# Altium schematic sheet MCU.SchDoc(MCU) (continued)

## Library data of the parts
C13 C19 = TMK212BBJ106KGHT: 25V 10uF X5R 卤10% 0805 Multilayer Ceramic Capacitors MLCC - SMD/SMT ROHS; Manufacturer: TAIYO YUDEN; Manufacturer Package Identifier: 0805 (2012 metric); Tolerance: 10%; Value: 10µF; Case Code (Imperial): 0805; Case Code (Metric): 2012; Case/Package: 0805; Category: Ceramic Capacitors; Component Kind: Standard; ComponentLink1Description: https://datasheet.octopart.com:443/TMK212BBJ106KGHT-Taiyo-Yuden-datasheet-181660554.pdf?src-supplier=element14+APAC; ComponentLink1URL: https://datasheet.octopart.com:443/TMK212BBJ106KGHT-Taiyo-Yuden-datasheet-181660554.pdf?src-supplier=element14+APAC; ComponentLink2Description: https://datasheet.octopart.com:443/TMK212BBJ106KGHT-Taiyo-Yuden-datasheet-140424376.pdf?src-supplier=Master+Electronics; ComponentLink2URL: https://datasheet.octopart.com:443/TMK212BBJ106KGHT-Taiyo-Yuden-datasheet-140424376.pdf?src-supplier=Master+Electronics; ComponentLink3Description: https://datasheet.octopart.com:443/TMK212BBJ106KGHT-Taiyo-Yuden-datasheet-137916716.pdf?src-supplier=Taiyo+Yuden+SCT; ComponentLink3URL: https://datasheet.octopart.com:443/TMK212BBJ106KGHT-Taiyo-Yuden-datasheet-137916716.pdf?src-supplier=Taiyo+Yuden+SCT; ComponentLink4Description: https://datasheet.octopart.com:443/TMK212BBJ106KGHT-Taiyo-Yuden-datasheet-97186031.pdf?src-supplier=Future+Electronics; ComponentLink4URL: https://datasheet.octopart.com:443/TMK212BBJ106KGHT-Taiyo-Yuden-datasheet-97186031.pdf?src-supplier=Future+Electronics; Country of Origin: Japan, Mainland China, Malaysia, South Korea; Dielectric: X5R; Dielectric Material: Ceramic; Export Control Classification Number (ECCN) Code: EAR99; Harmonized Tariff Schedule (HTS) Code: 8532.24.00.20; Height: 1.25mm; Introduction Date: 2014-09-25; Length: 2mm; Lifecycle Status: Production; Manufacturer Part Number: TMK212BBJ106KGHT; Material: Ceramic; Max Operating Temperature: 85°C; Min Operating Temperature: -55°C; Mounting Technology: Surface Mount; Number of Terminals: 2; Packaging: Tape & Reel (TR); Pricing: 1=0.3, 10=0.178, 50=0.1288, 100=0.1137, 500=0.08824 (USD); Ratings: AEC-Q200; REACH SVHC: Yes; RoHS: Compliant; Stock: 129716; Supplier: DigiKey; Supplier Part Number: 587-4334-6-ND; Termination: SMD/SMT; Thickness: 1.3462mm; Voltage Rating: 25V; Voltage Rating (DC): 25V; Weight: 5.499807mg; Width: 1.25mm; Library Name: Tremelo_Pedal.SchLib
C14-C18 C21 C23 = C0402C104K4RACAUTO: CAP CER 0.1UF 16V X7R 0402 / 0.1 µF ±10% 16V Ceramic Capacitor X7R 0402 (1005 Metric); Manufacturer: Yageo Group; Dissipation Factor: 3.5%; Value: 100nF; Case Code (Imperial): 0402; Case Code (Metric): 1005; Case/Package: 0402; Category: Ceramic Capacitors; China RoHS: Compliant; Component Kind: Standard; ComponentLink1Description: https://datasheet.octopart.com:443/C0402C104K4RACAUTO-KEMET-datasheet-178087915.pdf?src-supplier=IHS; ComponentLink1URL: https://datasheet.octopart.com:443/C0402C104K4RACAUTO-KEMET-datasheet-178087915.pdf?src-supplier=IHS; ComponentLink2Description: https://datasheet.octopart.com:443/C0402C104K4RACAUTO-KEMET-datasheet-137357759.pdf?src-supplier=Upverter; ComponentLink2URL: https://datasheet.octopart.com:443/C0402C104K4RACAUTO-KEMET-datasheet-137357759.pdf?src-supplier=Upverter; ComponentLink3Description: https://datasheet.octopart.com:443/C0402C104K4RACAUTO-KEMET-datasheet-149521626.pdf?src-supplier=LKR; ComponentLink3URL: https://datasheet.octopart.com:443/C0402C104K4RACAUTO-KEMET-datasheet-149521626.pdf?src-supplier=LKR; ComponentLink4Description: https://datasheet.octopart.com:443/C0402C104K4RACAUTO-KEMET-datasheet-102647416.pdf?src-supplier=Farnell; ComponentLink4URL: https://datasheet.octopart.com:443/C0402C104K4RACAUTO-KEMET-datasheet-102647416.pdf?src-supplier=Farnell; ComponentLink5Description: https://datasheet.octopart.com:443/C0402C104K4RACAUTO-KEMET-datasheet-138896278.pdf?src-supplier=Farnell; ComponentLink5URL: https://datasheet.octopart.com:443/C0402C104K4RACAUTO-KEMET-datasheet-138896278.pdf?src-supplier=Farnell; ComponentLink6Description: https://datasheet.octopart.com:443/C0402C104K4RACAUTO-Kemet-datasheet-14356739.pdf?src-supplier=Farnell; ComponentLink6URL: https://datasheet.octopart.com:443/C0402C104K4RACAUTO-Kemet-datasheet-14356739.pdf?src-supplier=Farnell; ComponentLink7Description: https://datasheet.octopart.com:443/C0402C104K4RAC-AUTO-Kemet-datasheet-11517047.pdf?src-supplier=Farnell; ComponentLink7URL: https://datasheet.octopart.com:443/C0402C104K4RAC-AUTO-Kemet-datasheet-11517047.pdf?src-supplier=Farnell; ComponentLink8Description: https://datasheet.octopart.com:443/C0402C104K4RACAUTO-KEMET-datasheet-153706760.pdf?src-supplier=Electro+Sonic; ComponentLink8URL: https://datasheet.octopart.com:443/C0402C104K4RACAUTO-KEMET-datasheet-153706760.pdf?src-supplier=Electro+Sonic; ComponentLink9Description: https://datasheet.octopart.com:443/C0402C104K4RACAUTO-KEMET-datasheet-37550250.pdf?src-supplier=Electro+Sonic; ComponentLink9URL: https://datasheet.octopart.com:443/C0402C104K4RACAUTO-KEMET-datasheet-37550250.pdf?src-supplier=Electro+Sonic; ComponentLink10Description: https://datasheet.octopart.com:443/C0402C104K4RACAUTO-KEMET-datasheet-81638344.pdf?src-supplier=Newark; ComponentLink10URL: https://datasheet.octopart.com:443/C0402C104K4RACAUTO-KEMET-datasheet-81638344.pdf?src-supplier=Newark; ComponentLink11Description: https://datasheet.octopart.com:443/C0402C104K4RAC-AUTO-Kemet-datasheet-10850653.pdf?src-supplier=Newark; ComponentLink11URL: https://datasheet.octopart.com:443/C0402C104K4RAC-AUTO-Kemet-datasheet-10850653.pdf?src-supplier=Newark; ComponentLink12Description: https://datasheet.octopart.com:443/C0402C104K4RACAUTO-KEMET-datasheet-176142086.pdf?src-supplier=Newark; ComponentLink12URL: https://datasheet.octopart.com:443/C0402C104K4RACAUTO-KEMET-datasheet-176142086.pdf?src-supplier=Newark; ComponentLink13Description: https://datasheet.octopart.com:443/C0402C104K4RACAUTO-KEMET-datasheet-101575470.pdf?src-supplier=Newark; ComponentLink13URL: https://datasheet.octopart.com:443/C0402C104K4RACAUTO-KEMET-datasheet-101575470.pdf?src-supplier=Newark; ComponentLink14Description: https://datasheet.octopart.com:443/C0402C104K4RACAUTO-Kemet-datasheet-66532075.pdf?src-supplier=element14+APAC; ComponentLink14URL: https://datasheet.octopart.com:443/C0402C104K4RACAUTO-Kemet-datasheet-66532075.pdf?src-supplier=element14+APAC; ComponentLink15Description: https://datasheet.octopart.com:443/C0402C104K4RACAUTO-Kemet-datasheet-17269585.pdf?src-supplier=element14+APAC; ComponentLink15URL: https://datasheet.octopart.com:443/C0402C104K4RACAUTO-Kemet-datasheet-17269585.pdf?src-supplier=element14+APAC; ComponentLink16Description: https://datasheet.octopart.com:443/C0402C104K4RACAUTO-Kemet-datasheet-66327795.pdf?src-supplier=element14+APAC; ComponentLink16URL: https://datasheet.octopart.com:443/C0402C104K4RACAUTO-Kemet-datasheet-66327795.pdf?src-supplier=element14+APAC; ComponentLink17Description: https://datasheet.octopart.com:443/C0402C104K4RACAUTO-KEMET-datasheet-83968784.pdf?src-supplier=TME; ComponentLink17URL: https://datasheet.octopart.com:443/C0402C104K4RACAUTO-KEMET-datasheet-83968784.pdf?src-supplier=TME; ComponentLink18Description: https://datasheet.octopart.com:443/C0402C104K4RACAUTO-KEMET-datasheet-98355702.pdf?src-supplier=TME; ComponentLink18URL: https://datasheet.octopart.com:443/C0402C104K4RACAUTO-KEMET-datasheet-98355702.pdf?src-supplier=TME; ComponentLink19Description: https://datasheet.octopart.com/C0402C104K4RACAUTO-KEMET-datasheet-84169754.pdf; ComponentLink19URL: https://datasheet.octopart.com/C0402C104K4RACAUTO-KEMET-datasheet-84169754.pdf; ComponentLink20Description: https://datasheet.octopart.com:443/C0402C104K4RACAUTO-KEMET-datasheet-189767464.pdf?src-supplier=ODG+(Origin+Data+Global); ComponentLink20URL: https://datasheet.octopart.com:443/C0402C104K4RACAUTO-KEMET-datasheet-189767464.pdf?src-supplier=ODG+(Origin+Data+Global); Country of Origin: Mexico; Depth: 500µm; Dielectric: X7R; Dielectric Material: Ceramic; Export Control Classification Number (ECCN) Code: EAR99; Harmonized Tariff Schedule (HTS) Code: 8532.24.00.20; Height: 500µm; Introduction Date: 2012-06-13; Length: 1mm; Lifecycle Status: Production; Manufacturer Part Number: C0402C104K4RACAUTO; Material: Ceramic; Max Operating Temperature: 125°C; Min Operating Temperature: -55°C; Mounting Technology: Surface Mount; Number of Terminals: 2; Packaging: Cut Tape; Pins: 2; Pricing: 1=0.19, 10=0.107, 50=0.0748, 100=0.0651, 500=0.04872 (USD); Radiation Hardening: No; Ratings: AEC-Q200; REACH SVHC: Yes; RoHS: Compliant; Schedule B: 8532240020, 8532240020|8532240020, 8532240020|8532240020|8532240020, 8532240020|8532240020|8532240020|8532240020; Stock: 1110690; Supplier: DigiKey; Supplier Part Number: 399-6828-6-ND; Termination: SMD/SMT; Thickness: 558.8µm; Tolerance: 10%; Voltage Rating: 16V; Voltage Rating (DC): 16V; Width: 500µm; Library Name: Tremelo_Pedal.SchLib
C20 = CC0603KRX7R7BB105: 16V 1uF X7R ±10% 0603 Multilayer Ceramic Capacitors MLCC - SMD/SMT ROHS; Manufacturer: Yageo Group; Dissipation Factor: 5%; Value: 1µF; Case Code (Imperial): 0603; Case Code (Metric): 1608; Case/Package: 0603; Category: Ceramic Capacitors; China RoHS: Compliant; Component Kind: Standard; ComponentLink1Description: https://datasheet.octopart.com:443/CC0603KRX7R7BB105-Yageo-datasheet-177165144.pdf?src-supplier=IHS; ComponentLink1URL: https://datasheet.octopart.com:443/CC0603KRX7R7BB105-Yageo-datasheet-177165144.pdf?src-supplier=IHS; ComponentLink2Description: https://datasheet.octopart.com:443/CC0603KRX7R7BB105-Yageo-datasheet-82288757.pdf?src-supplier=Upverter; ComponentLink2URL: https://datasheet.octopart.com:443/CC0603KRX7R7BB105-Yageo-datasheet-82288757.pdf?src-supplier=Upverter; ComponentLink3Description: https://datasheet.octopart.com/CC0603KRX7R7BB105-Yageo-datasheet-10883670.pdf; ComponentLink3URL: https://datasheet.octopart.com/CC0603KRX7R7BB105-Yageo-datasheet-10883670.pdf; ComponentLink4Description: https://datasheet.octopart.com/CC0603KRX7R7BB105-Yageo-datasheet-12526321.pdf; ComponentLink4URL: https://datasheet.octopart.com/CC0603KRX7R7BB105-Yageo-datasheet-12526321.pdf; ComponentLink5Description: https://datasheet.octopart.com:443/CC0603KRX7R7BB105-Yageo-datasheet-181968648.pdf?src-supplier=Newark; ComponentLink5URL: https://datasheet.octopart.com:443/CC0603KRX7R7BB105-Yageo-datasheet-181968648.pdf?src-supplier=Newark; ComponentLink6Description: https://datasheet.octopart.com:443/CC0603KRX7R7BB105-Yageo-datasheet-181968639.pdf?src-supplier=Newark; ComponentLink6URL: https://datasheet.octopart.com:443/CC0603KRX7R7BB105-Yageo-datasheet-181968639.pdf?src-supplier=Newark; ComponentLink7Description: https://datasheet.octopart.com:443/CC0603KRX7R7BB105-Yageo-datasheet-519481.pdf?src-supplier=Newark; ComponentLink7URL: https://datasheet.octopart.com:443/CC0603KRX7R7BB105-Yageo-datasheet-519481.pdf?src-supplier=Newark; ComponentLink8Description: https://datasheet.octopart.com:443/CC0603KRX7R7BB105-Yageo-datasheet-147453679.pdf?src-supplier=Newark; ComponentLink8URL: https://datasheet.octopart.com:443/CC0603KRX7R7BB105-Yageo-datasheet-147453679.pdf?src-supplier=Newark; ComponentLink9Description: https://datasheet.octopart.com:443/CC0603KRX7R7BB105-Yageo-datasheet-62336348.pdf?src-supplier=Farnell; ComponentLink9URL: https://datasheet.octopart.com:443/CC0603KRX7R7BB105-Yageo-datasheet-62336348.pdf?src-supplier=Farnell; ComponentLink10Description: https://datasheet.octopart.com:443/CC0603KRX7R7BB105-Yageo-datasheet-151948686.pdf?src-supplier=Farnell; ComponentLink10URL: https://datasheet.octopart.com:443/CC0603KRX7R7BB105-Yageo-datasheet-151948686.pdf?src-supplier=Farnell; ComponentLink11Description: https://datasheet.octopart.com:443/CC0603KRX7R7BB105-Yageo-datasheet-15895757.pdf?src-supplier=element14+APAC; ComponentLink11URL: https://datasheet.octopart.com:443/CC0603KRX7R7BB105-Yageo-datasheet-15895757.pdf?src-supplier=element14+APAC; ComponentLink12Description: https://datasheet.octopart.com:443/CC0603KRX7R7BB105-Yageo-Group-datasheet-184163374.pdf?src-supplier=LCSC; ComponentLink12URL: https://datasheet.octopart.com:443/CC0603KRX7R7BB105-Yageo-Group-datasheet-184163374.pdf?src-supplier=LCSC; ComponentLink13Description: https://datasheet.octopart.com:443/CC0603KRX7R7BB105-Yageo-datasheet-166511530.pdf?src-supplier=Future+Electronics; ComponentLink13URL: https://datasheet.octopart.com:443/CC0603KRX7R7BB105-Yageo-datasheet-166511530.pdf?src-supplier=Future+Electronics; ComponentLink14Description: https://datasheet.octopart.com:443/CC0603KRX7R7BB105-Yageo-datasheet-137700082.pdf?src-supplier=Future+Electronics; ComponentLink14URL: https://datasheet.octopart.com:443/CC0603KRX7R7BB105-Yageo-datasheet-137700082.pdf?src-supplier=Future+Electronics; ComponentLink15Description: https://datasheet.octopart.com:443/CC0603KRX7R7BB105-Yageo-datasheet-137700084.pdf?src-supplier=Future+Electronics; ComponentLink15URL: https://datasheet.octopart.com:443/CC0603KRX7R7BB105-Yageo-datasheet-137700084.pdf?src-supplier=Future+Electronics; ComponentLink16Description: https://datasheet.octopart.com:443/CC0603KRX7R7BB105-Yageo-datasheet-27626582.pdf?src-supplier=Future+Electronics; ComponentLink16URL: https://datasheet.octopart.com:443/CC0603KRX7R7BB105-Yageo-datasheet-27626582.pdf?src-supplier=Future+Electronics; ComponentLink17Description: https://datasheet.octopart.com:443/CC0603KRX7R7BB105-Yageo-Group-datasheet-165992755.pdf?src-supplier=ODG+(Origin+Data+Global); ComponentLink17URL: https://datasheet.octopart.com:443/CC0603KRX7R7BB105-Yageo-Group-datasheet-165992755.pdf?src-supplier=ODG+(Origin+Data+Global); ComponentLink18Description: https://datasheet.octopart.com:443/CC0603KRX7R7BB105-Yageo-datasheet-140260305.pdf?src-supplier=SOS+electronic; ComponentLink18URL: https://datasheet.octopart.com:443/CC0603KRX7R7BB105-Yageo-datasheet-140260305.pdf?src-supplier=SOS+electronic; ComponentLink19Description: https://datasheet.octopart.com:443/CC0603KRX7R7BB105-Yageo-datasheet-8701427.pdf?src-supplier=element14; ComponentLink19URL: https://datasheet.octopart.com:443/CC0603KRX7R7BB105-Yageo-datasheet-8701427.pdf?src-supplier=element14; ComponentLink20Description: https://datasheet.octopart.com:443/CC0603KRX7R7BB105-Yageo-datasheet-499490.pdf?src-supplier=element14; ComponentLink20URL: https://datasheet.octopart.com:443/CC0603KRX7R7BB105-Yageo-datasheet-499490.pdf?src-supplier=element14; ComponentLink21Description: https://datasheet.octopart.com:443/CC0603KRX7R7BB105-Yageo-datasheet-5301394.pdf?src-supplier=element14; ComponentLink21URL: https://datasheet.octopart.com:443/CC0603KRX7R7BB105-Yageo-datasheet-5301394.pdf?src-supplier=element14; ComponentLink22Description: https://datasheet.octopart.com:443/CC0603KRX7R7BB105-Yageo-datasheet-17600895.pdf?src-supplier=TME; ComponentLink22URL: https://datasheet.octopart.com:443/CC0603KRX7R7BB105-Yageo-datasheet-17600895.pdf?src-supplier=TME; ComponentLink23Description: http://sigma.octopart.com:80/rohs_statement/Surface-Mount-Ceramic-Multilayer-Capacitors%3B-General-Purpose-%26-High-Capacitance-Class-2%2C-X7R-Yageo-family-124.pdf?src-supplier=Compliance+Statements; ComponentLink23URL: http://sigma.octopart.com:80/rohs_statement/Surface-Mount-Ceramic-Multilayer-Capacitors%3B-General-Purpose-%26-High-Capacitance-Class-2%2C-X7R-Yageo-family-124.pdf?src-supplier=Compliance+Statements; ComponentLink24Description: http://sigma.octopart.com:80/reach_statement/Surface-Mount-Ceramic-Multilayer-Capacitors%3B-General-Purpose-%26-High-Capacitance-Class-2%2C-X7R-Yageo-family-125.pdf?src-supplier=Compliance+Statements; ComponentLink24URL: http://sigma.octopart.com:80/reach_statement/Surface-Mount-Ceramic-Multilayer-Capacitors%3B-General-Purpose-%26-High-Capacitance-Class-2%2C-X7R-Yageo-family-125.pdf?src-supplier=Compliance+Statements; ComponentLink25Description: http://sigma.octopart.com:80/conflict_mineral_statement/Yageo-company-3.pdf?src-supplier=Compliance+Statements; ComponentLink25URL: http://sigma.octopart.com:80/conflict_mineral_statement/Yageo-company-3.pdf?src-supplier=Compliance+Statements; ComponentLink26Description: http://sigma.octopart.com:80/rohs_statement/Yageo-company-2.pdf?src-supplier=Compliance+Statements; ComponentLink26URL: http://sigma.octopart.com:80/rohs_statement/Yageo-company-2.pdf?src-supplier=Compliance+Statements; Composition: Ceramic; Country of Origin: Mainland China, Taiwan; Depth: 800µm; Dielectric: X7R; Dielectric Material: Ceramic; Export Control Classification Number (ECCN) Code: EAR99; Halogen Free: Halogen Free; Harmonized Tariff Schedule (HTS) Code: 8532.24.00.20; Height: 800µm; Introduction Date: 2009-04-17; Lead Free: Lead Free; Length: 1.6mm; Lifecycle Status: Production; Manufacturer Part Number: CC0603KRX7R7BB105; Material: Ceramic; Max Operating Temperature: 125°C; Min Operating Temperature: -55°C; Mounting Technology: Surface Mount; Number of Terminals: 2; Pins: 2; Pricing: 1=0.17, 10=0.092, 50=0.0642, 100=0.0557, 500=0.04142 (USD); Radiation Hardening: No; REACH SVHC: Yes; RoHS: Compliant; Schedule B: 8532240020, 8532240020|8532240020, 8532240020|8532240020|8532240020, 8532240020|8532240020|8532240020|8532240020; Stock: 4673449; Supplier: DigiKey; Supplier Part Number: 311-1446-1-ND; Termination: SMD/SMT; Thickness: 889µm; Tolerance: 10%; Voltage: 16V; Voltage Rating: 16V; Voltage Rating (DC): 16V; Width: 800µm; Library Name: Tremelo_Pedal.SchLib
C22 = C0402C103K5RACAUTO: 50V 10nF X7R ±10% 0402 Multilayer Ceramic Capacitors MLCC - SMD/SMT ROHS; Manufacturer: Yageo Group; Case Code (Imperial): 0402; Case Code (Metric): 1005; Case/Package: 0402; Category: Ceramic Capacitors; China RoHS: Compliant; Component Kind: Standard; ComponentLink1Description: https://datasheet.octopart.com:443/C0402C103K5RACAUTO-KEMET-datasheet-178087915.pdf?src-supplier=IHS; ComponentLink1URL: https://datasheet.octopart.com:443/C0402C103K5RACAUTO-KEMET-datasheet-178087915.pdf?src-supplier=IHS; ComponentLink2Description: https://datasheet.octopart.com:443/C0402C103K5RACAUTO-KEMET-datasheet-137357759.pdf?src-supplier=Upverter; ComponentLink2URL: https://datasheet.octopart.com:443/C0402C103K5RACAUTO-KEMET-datasheet-137357759.pdf?src-supplier=Upverter; ComponentLink3Description: https://datasheet.octopart.com:443/C0402C103K5RACAUTO-KEMET-datasheet-149521614.pdf?src-supplier=LKR; ComponentLink3URL: https://datasheet.octopart.com:443/C0402C103K5RACAUTO-KEMET-datasheet-149521614.pdf?src-supplier=LKR; ComponentLink4Description: https://datasheet.octopart.com:443/C0402C103K5RACAUTO-Kemet-datasheet-66413394.pdf?src-supplier=element14+APAC; ComponentLink4URL: https://datasheet.octopart.com:443/C0402C103K5RACAUTO-Kemet-datasheet-66413394.pdf?src-supplier=element14+APAC; ComponentLink5Description: https://datasheet.octopart.com:443/C0402C103K5RACAUTO-Kemet-datasheet-66076605.pdf?src-supplier=element14+APAC; ComponentLink5URL: https://datasheet.octopart.com:443/C0402C103K5RACAUTO-Kemet-datasheet-66076605.pdf?src-supplier=element14+APAC; ComponentLink6Description: https://datasheet.octopart.com:443/C0402C103K5RACAUTO-Kemet-datasheet-41767020.pdf?src-supplier=Newark; ComponentLink6URL: https://datasheet.octopart.com:443/C0402C103K5RACAUTO-Kemet-datasheet-41767020.pdf?src-supplier=Newark; ComponentLink7Description: https://datasheet.octopart.com:443/C0402C103K5RACAUTO-KEMET-datasheet-74831190.pdf?src-supplier=Newark; ComponentLink7URL: https://datasheet.octopart.com:443/C0402C103K5RACAUTO-KEMET-datasheet-74831190.pdf?src-supplier=Newark; ComponentLink8Description: https://datasheet.octopart.com:443/C0402C103K5RACAUTO-KEMET-datasheet-169689514.pdf?src-supplier=Newark; ComponentLink8URL: https://datasheet.octopart.com:443/C0402C103K5RACAUTO-KEMET-datasheet-169689514.pdf?src-supplier=Newark; ComponentLink9Description: https://datasheet.octopart.com:443/C0402C103K5RACAUTO-Kemet-datasheet-66076775.pdf?src-supplier=Newark; ComponentLink9URL: https://datasheet.octopart.com:443/C0402C103K5RACAUTO-Kemet-datasheet-66076775.pdf?src-supplier=Newark; ComponentLink10Description: https://datasheet.octopart.com:443/C0402C103K5RACAUTO-KEMET-datasheet-153706760.pdf?src-supplier=Electro+Sonic; ComponentLink10URL: https://datasheet.octopart.com:443/C0402C103K5RACAUTO-KEMET-datasheet-153706760.pdf?src-supplier=Electro+Sonic; ComponentLink11Description: https://datasheet.octopart.com:443/C0402C103K5RACAUTO-KEMET-datasheet-138896278.pdf?src-supplier=Farnell; ComponentLink11URL: https://datasheet.octopart.com:443/C0402C103K5RACAUTO-KEMET-datasheet-138896278.pdf?src-supplier=Farnell; ComponentLink12Description: https://datasheet.octopart.com:443/C0402C103K5RACAUTO-KEMET-datasheet-101575464.pdf?src-supplier=Farnell; ComponentLink12URL: https://datasheet.octopart.com:443/C0402C103K5RACAUTO-KEMET-datasheet-101575464.pdf?src-supplier=Farnell; ComponentLink13Description: https://datasheet.octopart.com:443/C0402C103K5RACAUTO-KEMET-datasheet-130259053.pdf?src-supplier=TME; ComponentLink13URL: https://datasheet.octopart.com:443/C0402C103K5RACAUTO-KEMET-datasheet-130259053.pdf?src-supplier=TME; ComponentLink14Description: https://datasheet.octopart.com:443/C0402C103K5RACAUTO-KEMET-datasheet-130256447.pdf?src-supplier=TME; ComponentLink14URL: https://datasheet.octopart.com:443/C0402C103K5RACAUTO-KEMET-datasheet-130256447.pdf?src-supplier=TME; ComponentLink15Description: https://datasheet.octopart.com/C0402C103K5RACAUTO-KEMET-datasheet-84169754.pdf; ComponentLink15URL: https://datasheet.octopart.com/C0402C103K5RACAUTO-KEMET-datasheet-84169754.pdf; Contact Plating: Tin; Country of Origin: Mexico; Depth: 500µm; Dielectric: X7R; Dielectric Material: Ceramic; Export Control Classification Number (ECCN) Code: EAR99; Harmonized Tariff Schedule (HTS) Code: 8532.24.00.20; Height: 500µm; Introduction Date: 2012-06-13; Length: 1mm; Lifecycle Status: Production; Manufacturer Part Number: C0402C103K5RACAUTO; Material: Ceramic; Max Operating Temperature: 125°C; Min Operating Temperature: -55°C; Mounting Technology: Surface Mount; Number of Terminals: 2; Packaging: Cut Tape; Pins: 2; Pricing: 1=0.12, 10=0.065, 50=0.0444, 100=0.0382, 500=0.02784 (USD); Radiation Hardening: No; Ratings: AEC-Q200; REACH SVHC: Yes; RoHS: Compliant; Schedule B: 8532240020, 8532240020|8532240020, 8532240020|8532240020|8532240020, 8532240020|8532240020|8532240020|8532240020; Stock: 395422; Supplier: DigiKey; Supplier Part Number: 399-6826-1-ND; Thickness: 558.8µm; Tolerance: 10%; Value: 10nF; Voltage Rating: 50V; Voltage Rating (DC): 50V; Width: 500µm; Library Name: Tremelo_Pedal.SchLib
C24 = GRT188R61E475KE13D: 25V 4.7uF X5R ±10% 0603 Multilayer Ceramic Capacitors MLCC - SMD/SMT ROHS; Manufacturer: Murata; Manufacturer Lifecycle Status: IN PRODUCTION; Value: 4.7µF; Case Code (Imperial): 0603; Case Code (Metric): 1608; Case/Package: 0603; Category: Ceramic Capacitors; Component Kind: Standard; ComponentLink1Description: https://datasheet.octopart.com:443/GRT188R61E475KE13D-Murata-datasheet-67697750.pdf?src-supplier=Newark; ComponentLink1URL: https://datasheet.octopart.com:443/GRT188R61E475KE13D-Murata-datasheet-67697750.pdf?src-supplier=Newark; ComponentLink2Description: https://datasheet.octopart.com:443/GRT188R61E475KE13D-Murata-datasheet-141284646.pdf?src-supplier=Geoflip; ComponentLink2URL: https://datasheet.octopart.com:443/GRT188R61E475KE13D-Murata-datasheet-141284646.pdf?src-supplier=Geoflip; ComponentLink3Description: https://datasheet.octopart.com:443/GRT188R61E475KE13D-Murata-datasheet-179108051.pdf?src-supplier=Arrow.cn; ComponentLink3URL: https://datasheet.octopart.com:443/GRT188R61E475KE13D-Murata-datasheet-179108051.pdf?src-supplier=Arrow.cn; ComponentLink4Description: https://datasheet.octopart.com:443/GRT188R61E475KE13D-Murata-datasheet-165579666.pdf?src-supplier=TME; ComponentLink4URL: https://datasheet.octopart.com:443/GRT188R61E475KE13D-Murata-datasheet-165579666.pdf?src-supplier=TME; ComponentLink5Description: https://datasheet.octopart.com:443/GRT188R61E475KE13D-Murata-datasheet-140711327.pdf?src-supplier=Ciiva; ComponentLink5URL: https://datasheet.octopart.com:443/GRT188R61E475KE13D-Murata-datasheet-140711327.pdf?src-supplier=Ciiva; ComponentLink6Description: https://datasheet.octopart.com:443/GRT188R61E475KE13D-Murata-datasheet-138749127.pdf?src-supplier=Ciiva; ComponentLink6URL: https://datasheet.octopart.com:443/GRT188R61E475KE13D-Murata-datasheet-138749127.pdf?src-supplier=Ciiva; ComponentLink7Description: http://sigma.octopart.com:80/reach_statement/Murata-company-16.pdf?src-supplier=Compliance+Statements; ComponentLink7URL: http://sigma.octopart.com:80/reach_statement/Murata-company-16.pdf?src-supplier=Compliance+Statements; Dielectric: X5R; Dielectric Material: Ceramic; Export Control Classification Number (ECCN) Code: EAR99; Harmonized Tariff Schedule (HTS) Code: 8532.24.00.20; Height: 800µm; Introduction Date: 2016-01-27; Length: 1.6mm; Lifecycle Status: Production; Manufacturer Part Number: GRT188R61E475KE13D; Material: Ceramic; Max Operating Temperature: 85°C; Min Operating Temperature: -55°C; Mounting Technology: Surface Mount; Number of Terminals: 2; Packaging: Cut Tape; Pricing: 1=0.28, 10=0.163, 50=0.1176, 100=0.1038, 500=0.08046 (USD); Ratings: AEC-Q200; REACH SVHC: Yes; RoHS: Compliant; Schedule B: 8532240020, 8532240020|8532240020, 8532240020|8532240020|8532240020, 8532240020|8532240020|8532240020|8532240020; Stock: 456056; Supplier: DigiKey; Supplier Part Number: 490-12328-6-ND; Tolerance: 10%; Voltage Rating: 25V; Voltage Rating (DC): 25V; Width: 800µm; Library Name: Tremelo_Pedal.SchLib
FB2 = BLM18AG601SN1D: Ferrite Beads Multi-Layer 600Ohm 25% 100MHz 500mA 380mOhm DCR 0603 Automotive Paper T/R; Manufacturer: Murata; DC Resistance (DCR): 380mΩ; Impedance: 600Ω; Material: Ferrite, Steel; Number of Circuits: 1; Shielding: Unshielded; Value: 380mΩ; Case Code (Imperial): 0603; Case Code (Metric): 1608; Case/Package: 0603; Category: Ferrite Beads and Chips; Clock Frequency: 100MHz; Component Kind: Standard; ComponentLink1Description: https://datasheet.octopart.com:443/BLM18AG601SN1D-Murata-datasheet-180806579.pdf?src-supplier=IHS; ComponentLink1URL: https://datasheet.octopart.com:443/BLM18AG601SN1D-Murata-datasheet-180806579.pdf?src-supplier=IHS; ComponentLink2Description: https://datasheet.octopart.com:443/BLM18AG601SN1D-Murata-datasheet-41195.pdf?src-supplier=Upverter; ComponentLink2URL: https://datasheet.octopart.com:443/BLM18AG601SN1D-Murata-datasheet-41195.pdf?src-supplier=Upverter; ComponentLink3Description: https://datasheet.octopart.com:443/BLM18AG601SN1D-Murata-datasheet-11743096.pdf?src-supplier=Newark; ComponentLink3URL: https://datasheet.octopart.com:443/BLM18AG601SN1D-Murata-datasheet-11743096.pdf?src-supplier=Newark; ComponentLink4Description: https://datasheet.octopart.com:443/BLM18AG601SN1D-Murata-datasheet-5338592.pdf?src-supplier=Newark; ComponentLink4URL: https://datasheet.octopart.com:443/BLM18AG601SN1D-Murata-datasheet-5338592.pdf?src-supplier=Newark; ComponentLink5Description: https://datasheet.octopart.com:443/BLM18AG601SN1D-Murata-datasheet-511221.pdf?src-supplier=TME; ComponentLink5URL: https://datasheet.octopart.com:443/BLM18AG601SN1D-Murata-datasheet-511221.pdf?src-supplier=TME; ComponentLink6Description: https://datasheet.octopart.com:443/BLM18AG601SN1D-Murata-datasheet-135086656.pdf?src-supplier=TME; ComponentLink6URL: https://datasheet.octopart.com:443/BLM18AG601SN1D-Murata-datasheet-135086656.pdf?src-supplier=TME; ComponentLink7Description: https://datasheet.octopart.com:443/BLM18AG601SN1D-Murata-datasheet-5396529.pdf?src-supplier=Factory+Futures; ComponentLink7URL: https://datasheet.octopart.com:443/BLM18AG601SN1D-Murata-datasheet-5396529.pdf?src-supplier=Factory+Futures; ComponentLink8Description: https://datasheet.octopart.com:443/BLM18AG601SN1D-Murata-datasheet-141257898.pdf?src-supplier=Ciiva; ComponentLink8URL: https://datasheet.octopart.com:443/BLM18AG601SN1D-Murata-datasheet-141257898.pdf?src-supplier=Ciiva; ComponentLink9Description: https://datasheet.octopart.com:443/BLM18AG601SN1D-Murata-datasheet-138706784.pdf?src-supplier=Ciiva; ComponentLink9URL: https://datasheet.octopart.com:443/BLM18AG601SN1D-Murata-datasheet-138706784.pdf?src-supplier=Ciiva; ComponentLink10Description: https://datasheet.octopart.com:443/BLM18AG601SN1D-Murata-datasheet-78375072.pdf?src-supplier=Future+Electronics; ComponentLink10URL: https://datasheet.octopart.com:443/BLM18AG601SN1D-Murata-datasheet-78375072.pdf?src-supplier=Future+Electronics; ComponentLink11Description: https://datasheet.octopart.com:443/BLM18AG601SN1D-Murata-datasheet-58177255.pdf?src-supplier=Future+Electronics; ComponentLink11URL: https://datasheet.octopart.com:443/BLM18AG601SN1D-Murata-datasheet-58177255.pdf?src-supplier=Future+Electronics; ComponentLink12Description: https://datasheet.octopart.com/BLM18AG601SN1D-Murata-datasheet-37129980.pdf; ComponentLink12URL: https://datasheet.octopart.com/BLM18AG601SN1D-Murata-datasheet-37129980.pdf; ComponentLink13Description: https://datasheet.octopart.com:443/BLM18AG601SN1D-Murata-datasheet-14066397.pdf?src-supplier=iiiC; ComponentLink13URL: https://datasheet.octopart.com:443/BLM18AG601SN1D-Murata-datasheet-14066397.pdf?src-supplier=iiiC; ComponentLink14Description: https://datasheet.octopart.com:443/BLM18AG601SN1D-Murata-datasheet-9604654.pdf?src-supplier=RS+(Formerly+Allied+Electronics); ComponentLink14URL: https://datasheet.octopart.com:443/BLM18AG601SN1D-Murata-datasheet-9604654.pdf?src-supplier=RS+(Formerly+Allied+Electronics); ComponentLink15Description: https://datasheet.octopart.com:443/BLM18AG601SN1D-Murata-datasheet-13143.pdf?src-supplier=RS+(Formerly+Allied+Electronics); ComponentLink15URL: https://datasheet.octopart.com:443/BLM18AG601SN1D-Murata-datasheet-13143.pdf?src-supplier=RS+(Formerly+Allied+Electronics); ComponentLink16Description: https://datasheet.octopart.com:443/BLM18AG601SN1D-Murata-datasheet-7628479.pdf?src-supplier=Jameco+(USA); ComponentLink16URL: https://datasheet.octopart.com:443/BLM18AG601SN1D-Murata-datasheet-7628479.pdf?src-supplier=Jameco+(USA); ComponentLink17Description: https://datasheet.octopart.com:443/BLM18AG601SN1D-Murata-datasheet-11030792.pdf?src-supplier=Farnell; ComponentLink17URL: https://datasheet.octopart.com:443/BLM18AG601SN1D-Murata-datasheet-11030792.pdf?src-supplier=Farnell; ComponentLink18Description: http://sigma.octopart.com:80/reach_statement/Murata-company-16.pdf?src-supplier=Compliance+Statements; ComponentLink18URL: http://sigma.octopart.com:80/reach_statement/Murata-company-16.pdf?src-supplier=Compliance+Statements; Core Material: Ferrite; Country of Origin: Japan, Thailand; Current Rating: 500mA; DC Current: 500mA; Depth: 800µm; ESR: 380mΩ; Export Control Classification Number (ECCN) Code: EAR99; Frequency: 100MHz; Harmonized Tariff Schedule (HTS) Code: 8504.50.80.00; Height: 800µm; Introduction Date: 2001-08-28; Lead Free: Lead Free; Length: 1.6mm; Lifecycle Status: Production; Manufacturer Part Number: BLM18AG601SN1D; Max Current Rating: 500mA; Max DC Current: 500mA; Max Operating Temperature: 125°C; Military Standard: Not; Min Operating Temperature: -55°C; Mounting Technology: Surface Mount; Number of Lines: 1; Number of Terminals: 2; Packaging: Cut Tape; Pins: 2; Pricing: 1=0.1, 10=0.059, 25=0.0512, 50=0.046, 100=0.0413, 250=0.03556, 500=0.03166, 1000=0.02806 (USD); Radiation Hardening: No; REACH SVHC: Yes; RMS Current (Irms): 500mA; RoHS: Compliant; Schedule B: 8543706000, 8543706000|8543706000, 8543706000|8543706000|8543706000, 8543706000|8543706000|8543706000|8543706000; Stock: 1776674; Supplier: DigiKey; Supplier Part Number: 490-1014-6-ND; Termination: SMD/SMT; Test Frequency: 100MHz; Tolerance: 25%; Weight: 2.012816mg; Width: 800µm; Library Name: Tremelo_Pedal.SchLib
J4 = G800W304018EU: CONN, HEADER, 4POS, 1ROW, 2.54MM, TH; Available until stocks are exhausted; Manufacturer: Amphenol; Category: Card Edge Connectors; Component Kind: Standard; ComponentLink1Description: https://datasheet.octopart.com:443/G800W304018EU-Amphenol-datasheet-182327028.pdf?src-supplier=Electro+Sonic; ComponentLink1URL: https://datasheet.octopart.com:443/G800W304018EU-Amphenol-datasheet-182327028.pdf?src-supplier=Electro+Sonic; Contact Gender: Male; Contact Material: Brass; Lifecycle Status: Production; Manufacturer Part Number: G800W304018EU; Orientation: Straight; Pins: 4; Pricing: 1=0.1, 10=0.068, 100=0.0574, 500=0.05126, 1000=0.04881, 5000=0.04356, 10000=0.04148 (USD); RoHS: Compliant; Stock: 4421; Supplier: DigiKey; Supplier Part Number: 664-G800W304018EU-ND; Terminal Pitch: 2.54mm; Library Name: EcoCar_Accessory_Controller_V2.IntLib
R2 R3 = MCT06030D1002DP500: Res Thin Film 0603 10K Ohm 0.5% 1/10W ±25ppm/°C Molded SMD SMD Paper T/R; Manufacturer: Vishay; Max Power Dissipation: 125mW; Power Rating: 100mW; Value: 10kΩ; Voltage Rating: 75V; Case Code (Imperial): 0603; Case Code (Metric): 1608; Case/Package: 0603; Category: Chip SMD Resistors; China RoHS: Non-Compliant; Component Kind: Standard; ComponentLink1Description: https://datasheet.octopart.com:443/MCT06030D1002DP500-Vishay-datasheet-182027961.pdf?src-supplier=IHS; ComponentLink1URL: https://datasheet.octopart.com:443/MCT06030D1002DP500-Vishay-datasheet-182027961.pdf?src-supplier=IHS; ComponentLink2Description: https://datasheet.octopart.com:443/MCT06030D1002DP500-Vishay-datasheet-81842982.pdf?src-supplier=Future+Electronics; ComponentLink2URL: https://datasheet.octopart.com:443/MCT06030D1002DP500-Vishay-datasheet-81842982.pdf?src-supplier=Future+Electronics; ComponentLink3Description: https://datasheet.octopart.com:443/MCT06030D1002DP500-Vishay-datasheet-178522811.pdf?src-supplier=Newark; ComponentLink3URL: https://datasheet.octopart.com:443/MCT06030D1002DP500-Vishay-datasheet-178522811.pdf?src-supplier=Newark; ComponentLink4Description: https://datasheet.octopart.com:443/MCT06030D1002DP500-Vishay-datasheet-66078241.pdf?src-supplier=Newark; ComponentLink4URL: https://datasheet.octopart.com:443/MCT06030D1002DP500-Vishay-datasheet-66078241.pdf?src-supplier=Newark; ComponentLink5Description: https://datasheet.octopart.com:443/MCT06030D1002DP500-Vishay-Dale-datasheet-62311667.pdf?src-supplier=RS+(Formerly+Allied+Electronics); ComponentLink5URL: https://datasheet.octopart.com:443/MCT06030D1002DP500-Vishay-Dale-datasheet-62311667.pdf?src-supplier=RS+(Formerly+Allied+Electronics); ComponentLink6Description: https://datasheet.octopart.com/MCT06030D1002DP500-Vishay-datasheet-12543201.pdf; ComponentLink6URL: https://datasheet.octopart.com/MCT06030D1002DP500-Vishay-datasheet-12543201.pdf; ComponentLink7Description: https://datasheet.octopart.com/MCT06030D1002DP500-Vishay-datasheet-12532999.pdf; ComponentLink7URL: https://datasheet.octopart.com/MCT06030D1002DP500-Vishay-datasheet-12532999.pdf; ComponentLink8Description: https://datasheet.octopart.com/MCT06030D1002DP500-Vishay-datasheet-12520289.pdf; ComponentLink8URL: https://datasheet.octopart.com/MCT06030D1002DP500-Vishay-datasheet-12520289.pdf; ComponentLink9Description: https://datasheet.octopart.com/MCT06030D1002DP500-Vishay-datasheet-12510709.pdf; ComponentLink9URL: https://datasheet.octopart.com/MCT06030D1002DP500-Vishay-datasheet-12510709.pdf; ComponentLink10Description: http://sigma.octopart.com:80/rohs_statement/Vishay-company-15.pdf?src-supplier=Compliance+Statements; ComponentLink10URL: http://sigma.octopart.com:80/rohs_statement/Vishay-company-15.pdf?src-supplier=Compliance+Statements; Composition: Thin Film; Contact Plating: Tin; Country of Origin: Germany, Israel; Depth: 850µm; Export Control Classification Number (ECCN) Code: EAR99; Harmonized Tariff Schedule (HTS) Code: 8533.21.00.30; Height: 450µm; Introduction Date: 2008-03-17; Lead Free: Lead Free; Length: 1.55mm; Lifecycle Status: Production; Manufacturer Part Number: MCT06030D1002DP500; Max Operating Temperature: 125°C; Military Standard: Not; Min Operating Temperature: -55°C; Mounting Technology: Surface Mount; Number of Terminals: 2; Number of Terminations: 2; Pricing: 1=0.1, 10=0.066, 50=0.058, 100=0.0548, 500=0.04808 (USD); Radiation Hardening: No; REACH SVHC: Yes; RoHS: Compliant; Schedule B: 8533210045, 8533210045|8533210045|8533210045|8533210045|8533210045; Stock: 18426; Supplier: DigiKey; Supplier Part Number: 749-1695-6-ND; Temperature Coefficient: 25ppm/°C; Tolerance: 0.5%; Voltage Rating (DC): 75V; Width: 850µm; Working Voltage: 75V; Library Name: Tremelo_Pedal.SchLib
SW1 = CT11024.3F260: Switch Tactile Spst-no 0.05A 50V; Manufacturer: CIT Relay & Switch; Contact Resistance: 50mΩ; Dielectric Material: Polyester; Electrical Life: 100kcycles; Insulation Resistance: 100MΩ; Max Current Rating: 50mA; Number of Positions: 1; Operating Force: 3.04N; Throw Configuration: SPST; Category: Tactile Switches; Component Kind: Standard; Contact Plating: Silver; Export Control Classification Number (ECCN) Code: EAR99; Harmonized Tariff Schedule (HTS) Code: 8536.50.90.40; Height: 3.5mm; Length: 6mm; Manufacturer Part Number: CT11024.3F260; Max Operating Temperature: 85°C; Max Voltage Rating (DC): 50V; Min Operating Temperature: -40°C; Pricing: 1=0.16, 10=0.139, 25=0.13, 100=0.1171, 250=0.10872, 500=0.10264, 2000=0.09146, 4000=0.08647, 6000=0.08376 (USD); Stock: 9461; Supplier: DigiKey; Supplier Part Number: 2449-CT11024.3F260-ND; Terminal Material: Brass; Termination: Solder; Library Name: EcoCar_Accessory_Controller_V2.IntLib
SW2 = OS102011MS2QN1: C & K COMPONENTS - OS102011MS2QN1 - Slide Switch, SPDT, On-On, Through Hole, OS Series, 100 mA; Actuator Length: 3.9878mm; Actuator Material: Polyoxymethylene; Contact Current Rating: 100mA; Contact Resistance: 20mΩ; Contact Style: Non-Shorting; Dielectric Material: Phenolic; Electrical Life: 10kcycles; Insulation Resistance: 100MΩ; Max Voltage Rating (DC): 12V; Number of Positions: 2; Switch Travel: 2mm; Category: Slide Switches; China RoHS: Compliant; Color: Black; Component Kind: Standard; ComponentLink1Description: https://datasheet.octopart.com:443/OS102011MS2QN1-C%26K-Components-datasheet-165712316.pdf?src-supplier=Newark; ComponentLink1URL: https://datasheet.octopart.com:443/OS102011MS2QN1-C%26K-Components-datasheet-165712316.pdf?src-supplier=Newark; ComponentLink2Description: https://datasheet.octopart.com:443/OS102011MS2QN1-C%26K-Components-datasheet-44395687.pdf?src-supplier=Newark; ComponentLink2URL: https://datasheet.octopart.com:443/OS102011MS2QN1-C%26K-Components-datasheet-44395687.pdf?src-supplier=Newark; ComponentLink3Description: https://datasheet.octopart.com:443/OS102011MS2QN1-ITT-datasheet-13440078.pdf?src-supplier=Newark; ComponentLink3URL: https://datasheet.octopart.com:443/OS102011MS2QN1-ITT-datasheet-13440078.pdf?src-supplier=Newark; ComponentLink4Description: https://datasheet.octopart.com:443/OS102011MS2QN1-ITT-datasheet-8462896.pdf?src-supplier=Newark; ComponentLink4URL: https://datasheet.octopart.com:443/OS102011MS2QN1-ITT-datasheet-8462896.pdf?src-supplier=Newark; ComponentLink5Description: https://datasheet.octopart.com:443/OS102011MS2QN1-ITT-datasheet-9645547.pdf?src-supplier=IHS; ComponentLink5URL: https://datasheet.octopart.com:443/OS102011MS2QN1-ITT-datasheet-9645547.pdf?src-supplier=IHS; ComponentLink6Description: https://datasheet.octopart.com:443/OS102011MS2QN1-C%26K-Components-datasheet-141913688.pdf?src-supplier=Upverter; ComponentLink6URL: https://datasheet.octopart.com:443/OS102011MS2QN1-C%26K-Components-datasheet-141913688.pdf?src-supplier=Upverter; ComponentLink7Description: https://datasheet.octopart.com:443/OS102011MS2QN1-C%26K-Components-datasheet-100062965.pdf?src-supplier=Sager+Electronics; ComponentLink7URL: https://datasheet.octopart.com:443/OS102011MS2QN1-C%26K-Components-datasheet-100062965.pdf?src-supplier=Sager+Electronics; ComponentLink8Description: https://datasheet.octopart.com:443/OS102011MS2QN1-C%26K-Components-datasheet-77351947.pdf?src-supplier=Electro+Sonic; ComponentLink8URL: https://datasheet.octopart.com:443/OS102011MS2QN1-C%26K-Components-datasheet-77351947.pdf?src-supplier=Electro+Sonic; ComponentLink9Description: https://datasheet.octopart.com:443/OS102011MS2QN1-C%26K-Components-datasheet-142755260.pdf?src-supplier=Electro+Sonic; ComponentLink9URL: https://datasheet.octopart.com:443/OS102011MS2QN1-C%26K-Components-datasheet-142755260.pdf?src-supplier=Electro+Sonic; ComponentLink10Description: https://datasheet.octopart.com:443/OS102011MS2QN1-ITT-datasheet-24578929.pdf?src-supplier=Electro+Sonic; ComponentLink10URL: https://datasheet.octopart.com:443/OS102011MS2QN1-ITT-datasheet-24578929.pdf?src-supplier=Electro+Sonic; ComponentLink11Description: https://datasheet.octopart.com:443/OS102011MS2QN1-ITT-datasheet-14434867.pdf?src-supplier=Electro+Sonic; ComponentLink11URL: https://datasheet.octopart.com:443/OS102011MS2QN1-ITT-datasheet-14434867.pdf?src-supplier=Electro+Sonic; ComponentLink12Description: https://datasheet.octopart.com:443/OS102011MS2QN1-C%26K-Components-datasheet-150821956.pdf?src-supplier=Burklin+Elektronik; ComponentLink12URL: https://datasheet.octopart.com:443/OS102011MS2QN1-C%26K-Components-datasheet-150821956.pdf?src-supplier=Burklin+Elektronik; ComponentLink13Description: https://datasheet.octopart.com:443/OS102011MS2QN1-C%26K-Components-datasheet-67873706.pdf?src-supplier=HQonline; ComponentLink13URL: https://datasheet.octopart.com:443/OS102011MS2QN1-C%26K-Components-datasheet-67873706.pdf?src-supplier=HQonline; ComponentLink14Description: https://datasheet.octopart.com:443/OS102011MS2QN1-C%26K-Components-datasheet-62111170.pdf?src-supplier=Future+Electronics; ComponentLink14URL: https://datasheet.octopart.com:443/OS102011MS2QN1-C%26K-Components-datasheet-62111170.pdf?src-supplier=Future+Electronics; ComponentLink15Description: https://datasheet.octopart.com:443/OS102011MS2QN1-ITT-datasheet-128837.pdf?src-supplier=DigiKey; ComponentLink15URL: https://datasheet.octopart.com:443/OS102011MS2QN1-ITT-datasheet-128837.pdf?src-supplier=DigiKey; ComponentLink16Description: https://datasheet.octopart.com:443/OS102011MS2QN1-C%26K-datasheet-542779.pdf?src-supplier=Farnell; ComponentLink16URL: https://datasheet.octopart.com:443/OS102011MS2QN1-C%26K-datasheet-542779.pdf?src-supplier=Farnell; ComponentLink17Description: https://datasheet.octopart.com:443/OS102011MS2QN1-C%26K-Components-datasheet-62112609.pdf?src-supplier=RS+(Formerly+Allied+Electronics); ComponentLink17URL: https://datasheet.octopart.com:443/OS102011MS2QN1-C%26K-Components-datasheet-62112609.pdf?src-supplier=RS+(Formerly+Allied+Electronics); ComponentLink18Description: http://sigma.octopart.com:80/reach_statement/ITT-company-18.pdf?src-supplier=Compliance+Statements; ComponentLink18URL: http://sigma.octopart.com:80/reach_statement/ITT-company-18.pdf?src-supplier=Compliance+Statements; Contact Material: Brass; Contact Plating: Silver; Country of Origin: Mainland China; Depth: 4.3mm; Export Control Classification Number (ECCN) Code: EAR99; Harmonized Tariff Schedule (HTS) Code: 8536.50.90.50; Height: 4mm; Housing Material: Resin; Introduction Date: 2006-02-08; Length: 8.6mm; Lifecycle Status: Production; Manufacturer: C&K Components; Manufacturer Part Number: OS102011MS2QN1; Max Current Rating: 100mA; Max Operating Temperature: 70°C; Mechanical Life: 10kcycles; Min Operating Temperature: -30°C; Mounting Technology: PCB, Through Hole; Orientation: Vertical; Packaging: Bulk; Pins: 3; Pricing: 1=0.8, 10=0.683, 25=0.6356, 100=0.567, 250=0.52524, 500=0.49608, 1800=0.44841, 3600=0.42613, 5400=0.41422 (USD); Radiation Hardening: No; REACH SVHC: Yes; RoHS: Compliant; Stock: 48265; Supplier: DigiKey; Supplier Part Number: CKN9565-ND; Switch Function: On-On; Terminal Material: Brass; Termination: Solder; Throw Configuration: SPDT; Voltage Rating (DC): 12V; Library Name: EcoCar_Accessory_Controller_V2.IntLib
U4 = STM32F446RET6: MCU 32-bit ARM Cortex M4 RISC 512KB Flash 2.5V/3.3V Medical 64-Pin LQFP Frame; Manufacturer: STMicroelectronics; ADC Channels (Resolution): 16-Ch 12-Bit; Clock Frequency: 16MHz; Core Architecture: ARM Cortex-M4; DAC Channels (Resolution): 2-Ch 12-Bit; Data Bus Width: 32b; EEPROM Memory Size: 0B; Frequency: 180MHz; Interface: IrDA, LIN, USB; Max Junction Temperature (Tj): 105°C; Max Power Dissipation: 345mW; Max Supply Current: 115mA; Max Supply Voltage: 3.6V; Min Supply Voltage: 1.7V; Nominal Supply Current: 1.12µA; Number of A/D Converters: 3; Number of ADC Channels: 16; Number of D/A Converters: 2; Number of I2C Channels: 4; Number of I/Os: 50; Operating Supply Voltage: 3.3V; Oscillator Type: Internal; Peripherals: LCD, POR; RAM Size: 128kB; Watchdog Timer: Yes; Ambient Temperature Range High: 85°C; Case/Package: QFP; Category: Microcontrollers; China RoHS: Compliant; Component Kind: Standard; ComponentLink1Description: https://datasheet.octopart.com:443/STM32F446RET6-STMicroelectronics-datasheet-159144890.pdf?src-supplier=IHS; ComponentLink1URL: https://datasheet.octopart.com:443/STM32F446RET6-STMicroelectronics-datasheet-159144890.pdf?src-supplier=IHS; ComponentLink2Description: https://datasheet.octopart.com:443/STM32F446RET6-STMicroelectronics-datasheet-140260407.pdf?src-supplier=ODG+(Origin+Data+Global); ComponentLink2URL: https://datasheet.octopart.com:443/STM32F446RET6-STMicroelectronics-datasheet-140260407.pdf?src-supplier=ODG+(Origin+Data+Global); ComponentLink3Description: https://datasheet.octopart.com:443/STM32F446RET6-STMicroelectronics-datasheet-58295083.pdf?src-supplier=Future+Electronics; ComponentLink3URL: https://datasheet.octopart.com:443/STM32F446RET6-STMicroelectronics-datasheet-58295083.pdf?src-supplier=Future+Electronics; ComponentLink4Description: https://datasheet.octopart.com:443/STM32F446RET6-STMicroelectronics-datasheet-41797127.pdf?src-supplier=element14+APAC; ComponentLink4URL: https://datasheet.octopart.com:443/STM32F446RET6-STMicroelectronics-datasheet-41797127.pdf?src-supplier=element14+APAC; ComponentLink5Description: https://datasheet.octopart.com:443/STM32F446RET6-STMicroelectronics-datasheet-43913012.pdf?src-supplier=element14+APAC; ComponentLink5URL: https://datasheet.octopart.com:443/STM32F446RET6-STMicroelectronics-datasheet-43913012.pdf?src-supplier=element14+APAC; ComponentLink6Description: https://datasheet.octopart.com:443/STM32F446RET6-STMicroelectronics-datasheet-34142437.pdf?src-supplier=STMicroelectronics; ComponentLink6URL: https://datasheet.octopart.com:443/STM32F446RET6-STMicroelectronics-datasheet-34142437.pdf?src-supplier=STMicroelectronics; ComponentLink7Description: https://datasheet.octopart.com:443/STM32F446RET6-STMicroelectronics-datasheet-83961502.pdf?src-supplier=SHENGYU+ELECTRONICS; ComponentLink7URL: https://datasheet.octopart.com:443/STM32F446RET6-STMicroelectronics-datasheet-83961502.pdf?src-supplier=SHENGYU+ELECTRONICS; ComponentLink8Description: https://datasheet.octopart.com:443/STM32F446RET6-STMicroelectronics-datasheet-48572338.pdf?src-supplier=TME; ComponentLink8URL: https://datasheet.octopart.com:443/STM32F446RET6-STMicroelectronics-datasheet-48572338.pdf?src-supplier=TME; Country of Origin: Mainland China, Philippines, Taiwan; Export Control Classification Number (ECCN) Code: 3A991.A.2; Flash Memory Size: 512kB; Harmonized Tariff Schedule (HTS) Code: 8542.31.00.25; Height: 1.6mm; Introduction Date: 2015-03-16; Lead Free: Lead Free; Length: 10mm; Lifecycle Status: Production; Manufacturer Part Number: STM32F446RET6; Max Operating Temperature: 85°C; Memory Format: FLASH; Memory Size: 512kB; Min Operating Temperature: -40°C; Mounting Technology: Surface Mount; Number of Channels: 16; Number of Programmable I/O: 50; Number of PWM Channels: 4; Number of SPI Channels: 3; Number of Terminals: 64; Number of Timers/Counters: 2; Number of UART Channels: 2; Number of USART Channels: 4; Number of USB Channels: 2; Pins: 64; Pricing: 10=4.23, 20=4.18, 40=4.13, 75=4.09, 150=4.01 (USD); REACH SVHC: Yes; RoHS: Compliant; Schedule B: 8542310000, 8542310000|8542310000|8542310000|8542310000|8542310000|8542310000|8542310000|8542310000|8542310000|8542310000|8542310000|8542310000|8542310000|8542310000|8542310000|8542310000|8542310000|8542310000|8542310000|8542310000|8542310000|8542310000|8542310000|85; Stock: 1490; Supplier: Future Electronics; Supplier Part Number: STM32F446RET6; Temperature Grade: Industrial; Terminal Pitch: 500µm; Width: 10mm; Library Name: EcoCar_Light_Controller.IntLib
X1 = ASDM1-8.000MHZ-LC-T: Oscillator MEMS 8MHz ±50ppm (Stability) 15pF LVCMOS 55% 3.3V 4-Pin QFN SMD T/R; Manufacturer: Abracon; Fall Time: 2ns; Frequency Tolerance: 0.005%; Max Junction Temperature (Tj): 150°C; Max Supply Current: 10mA; Max Supply Voltage: 3.6V; Min Supply Voltage: 3V; Output Type: CMOS; Case/Package: SMD/SMT; Category: Oscillators; China RoHS: Compliant; Component Kind: Standard; ComponentLink1Description: https://datasheet.octopart.com:443/ASDM1-8.000MHZ-LC-T-Abracon-datasheet-182211861.pdf?src-supplier=IHS; ComponentLink1URL: https://datasheet.octopart.com:443/ASDM1-8.000MHZ-LC-T-Abracon-datasheet-182211861.pdf?src-supplier=IHS; ComponentLink2Description: https://datasheet.octopart.com:443/ASDM1-8.000MHZ-LC-T-Abracon-datasheet-10979380.pdf?src-supplier=element14+APAC; ComponentLink2URL: https://datasheet.octopart.com:443/ASDM1-8.000MHZ-LC-T-Abracon-datasheet-10979380.pdf?src-supplier=element14+APAC; ComponentLink3Description: https://datasheet.octopart.com:443/ASDM1-8.000MHZ-LC-T-Abracon-datasheet-8454059.pdf?src-supplier=element14+APAC; ComponentLink3URL: https://datasheet.octopart.com:443/ASDM1-8.000MHZ-LC-T-Abracon-datasheet-8454059.pdf?src-supplier=element14+APAC; ComponentLink4Description: https://datasheet.octopart.com:443/ASDM1-8.000MHZ-LC-T-Abracon-datasheet-103094565.pdf?src-supplier=JRH+Electronics; ComponentLink4URL: https://datasheet.octopart.com:443/ASDM1-8.000MHZ-LC-T-Abracon-datasheet-103094565.pdf?src-supplier=JRH+Electronics; ComponentLink5Description: https://datasheet.octopart.com:443/ASDM1-8.000MHZ-LC-T-Abracon-datasheet-189095977.pdf?src-supplier=Master+Electronics; ComponentLink5URL: https://datasheet.octopart.com:443/ASDM1-8.000MHZ-LC-T-Abracon-datasheet-189095977.pdf?src-supplier=Master+Electronics; ComponentLink6Description: https://datasheet.octopart.com:443/ASDM1-8.000MHZ-LC-T-Abracon-datasheet-37142401.pdf?src-supplier=Newark; ComponentLink6URL: https://datasheet.octopart.com:443/ASDM1-8.000MHZ-LC-T-Abracon-datasheet-37142401.pdf?src-supplier=Newark; ComponentLink7Description: https://sigma.octopart.com:443/141160256/technical_drawing/Abracon-ASDM1-8.000MHZ-LC-T.pdf?src-supplier=Abracon+SCT; ComponentLink7URL: https://sigma.octopart.com:443/141160256/technical_drawing/Abracon-ASDM1-8.000MHZ-LC-T.pdf?src-supplier=Abracon+SCT; ComponentLink8Description: http://sigma.octopart.com:80/conflict_mineral_statement/Abracon-company-27.pdf?src-supplier=Compliance+Statements; ComponentLink8URL: http://sigma.octopart.com:80/conflict_mineral_statement/Abracon-company-27.pdf?src-supplier=Compliance+Statements; Country of Origin: Thailand; Frequency: 8MHz; Frequency Stability: 50ppm; Height: 900µm; Introduction Date: 2011-07-21; Lead Free: Lead Free; Length: 2.5mm; Lifecycle Status: Production; Load Capacitance: 15pF; Manufacturer Part Number: ASDM1-8.000MHZ-LC-T; Max Operating Temperature: 85°C; Min Operating Temperature: -40°C; Mounting Technology: Surface Mount; Nominal Supply Voltage (DC): 3.3V; Number of Terminals: 4; Operating Supply Voltage: 3.3V; Packaging: Tape & Reel (TR); Pins: 4; Pricing: 1=2.96, 10=2.568, 25=2.4288, 50=2.3284, 100=2.2321, 250=2.111, 500=2.02382 (USD); Radiation Hardening: No; REACH SVHC: Yes; Rise Time: 2ns; RoHS: Compliant; Schedule B: 8542320050; Stock: 9192; Supplier: DigiKey; Supplier Part Number: 535-9781-6-ND; Termination: SMD/SMT; Width: 2mm; Library Name: Tremelo_Pedal.SchLib
